# Altium schematic sheet Serial Monitor RS-232 Module Schematic.SchDoc("Serial Monitor RS-232 Module Schematic")
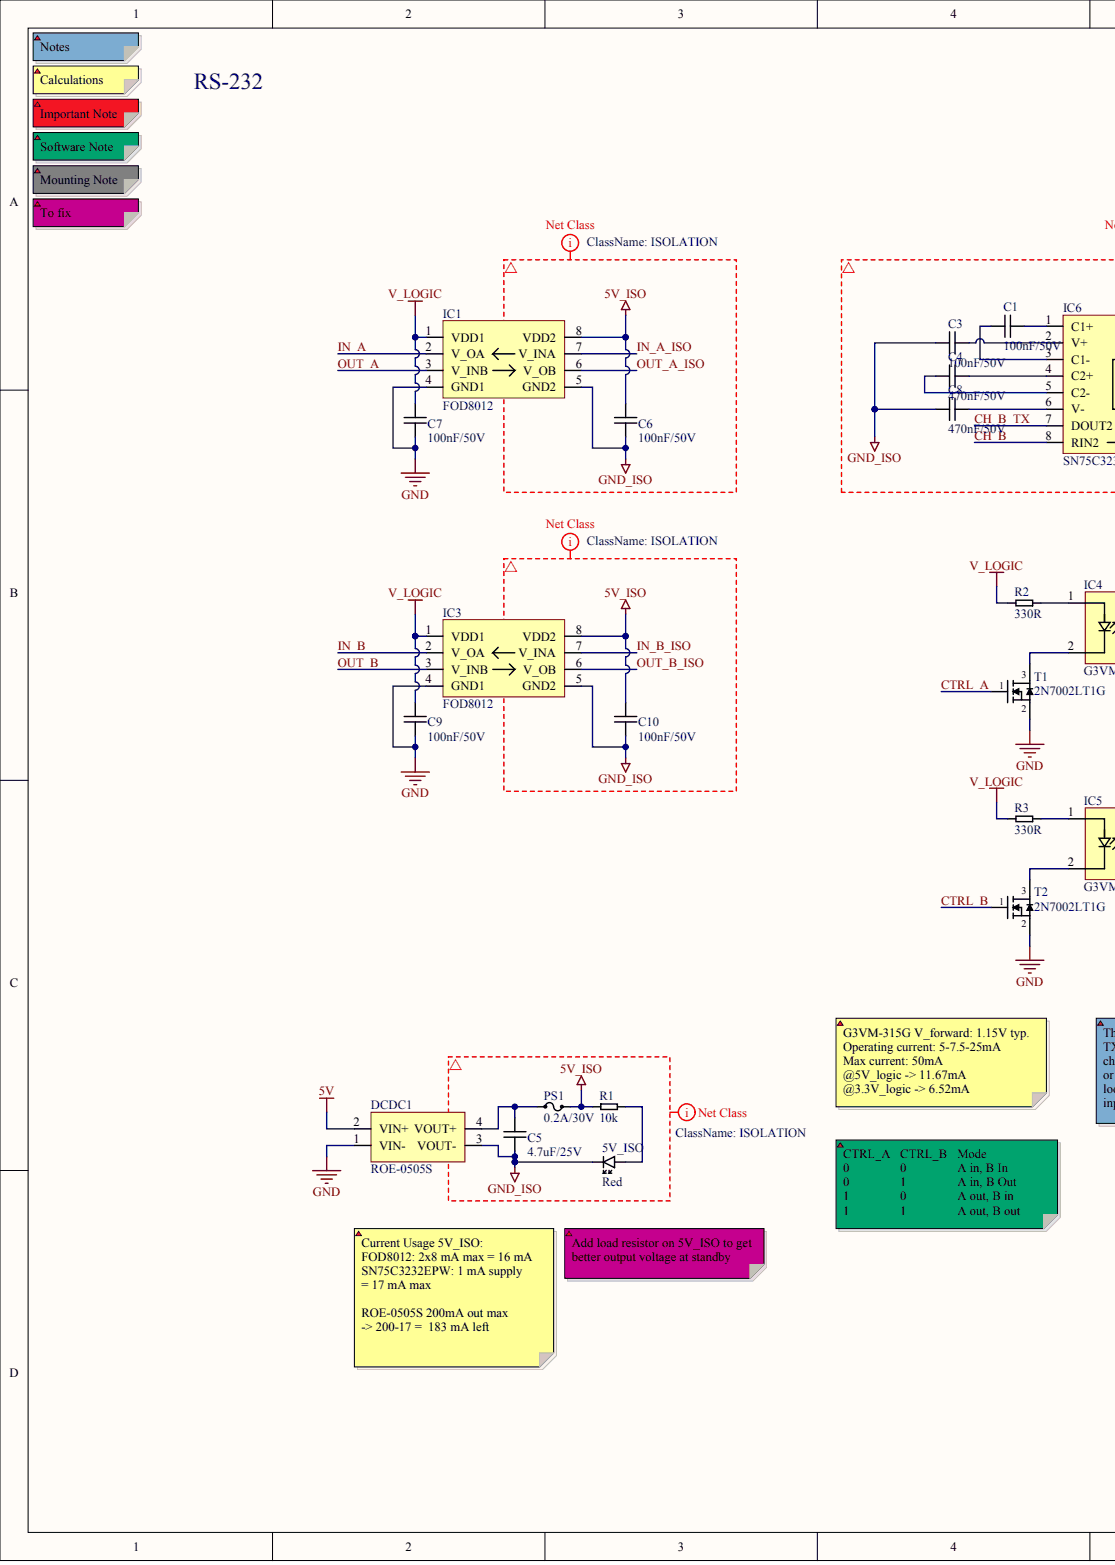
[diagram p0: Serial Monitor RS-232 Module Schematic.SchDoc, left]
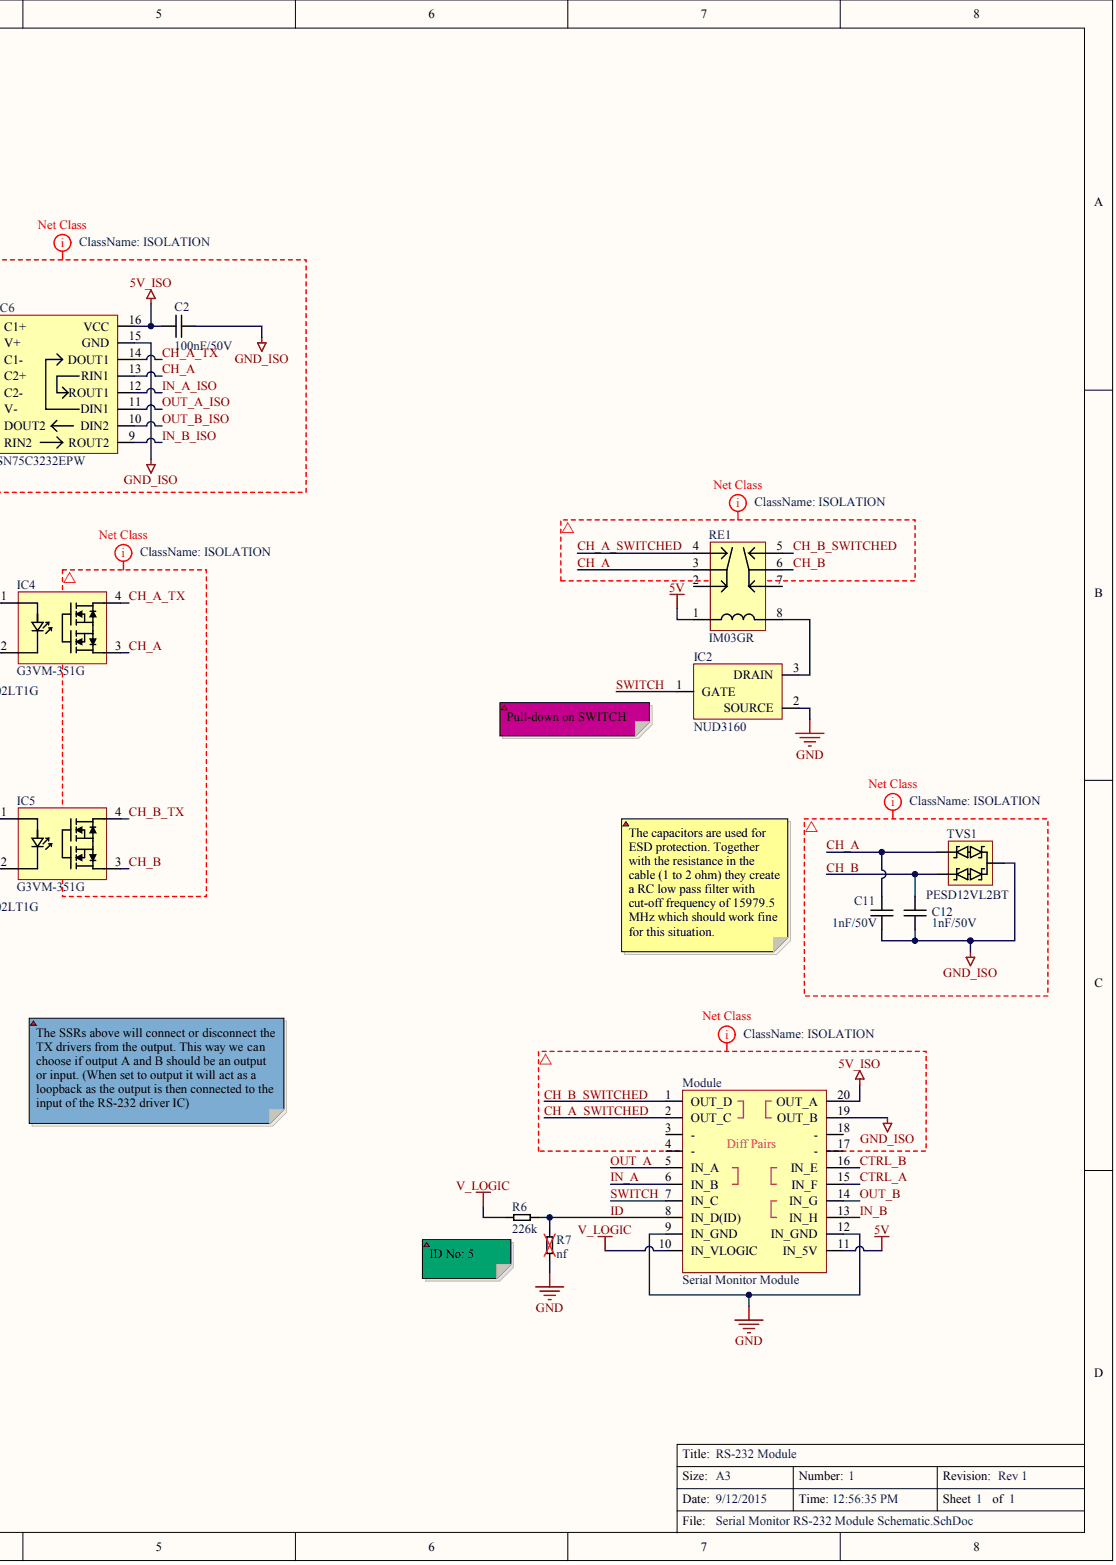
[diagram p1: Serial Monitor RS-232 Module Schematic.SchDoc, right]

## Parts
5V_ISO  value Red  (hidden comment: LED_0603_Red)
C1  value 100nF/50V  [0603]  (hidden comment: Cap_0603_100nF/50V)
C2  value 100nF/50V  [0603]  (hidden comment: Cap_0603_100nF/50V)
C3  value 100nF/50V  [0603]  (hidden comment: Cap_0603_100nF/50V)
C4  value 470nF/50V  [0603]  (hidden comment: Cap_0603_470nF/50V)
C5  value 4.7uF/25V  [0805]  (hidden comment: Cap_0805_4.7uF/25V)
C6  value 100nF/50V  [0603]  (hidden comment: Cap_0603_100nF/50V)
C7  value 100nF/50V  [0603]  (hidden comment: Cap_0603_100nF/50V)
C8  value 470nF/50V  [0603]  (hidden comment: Cap_0603_470nF/50V)
C9  value 100nF/50V  [0603]  (hidden comment: Cap_0603_100nF/50V)
C10  value 100nF/50V  [0603]  (hidden comment: Cap_0603_100nF/50V)
C11  value 1nF/50V  [0603]  (hidden comment: Cap_0603_1nF/50V)
C12  value 1nF/50V  [0603]  (hidden comment: Cap_0603_1nF/50V)
DCDC1  ROE-0505S  [4-SIP]
IC1  FOD8012
IC2  NUD3160
IC3  FOD8012
IC4  G3VM-351G
IC5  G3VM-351G
IC6  SN75C3232EPW
Module  Serial Monitor Module
PS1  value 0.2A/30V  [SMD 1206]  (hidden comment: Polyswitch_1206_0.2Ahold_30V)
R1  value 10k  [0603]  (hidden comment: Res_0603_10k)
R2  value 330R  [0603]  (hidden comment: Res_0603_330R)
R3  value 330R  [0603]  (hidden comment: Res_0603_330R)
R6  value 226k  [0603]  (hidden comment: Res_0603_226k)
R7  value nf  [0603]  (hidden comment: Res_0603_nf)
RE1  value IM03GR  Part No.: IM03GR  (hidden comment: Relay IM03GR)
T1  2N7002LT1G  [SOT-23]
T2  2N7002LT1G  [SOT-23]
TVS1  PESD12VL2BT

## Nets
5V: DCDC1.2(VIN+) Module.11(IN_5V) RE1.1
5V_ISO: C2.1 C6.2 C10.2 IC1.8(VDD2) IC3.8(VDD2) IC6.16(VCC) Module.20(OUT_A) PS1.2 R1.2
GND: C7.1 C9.1 DCDC1.1(VIN-) IC1.4(GND1) IC2.2 IC3.4(GND1) Module.9(IN_GND) Module.12(IN_GND) R7.2 T1.2 T2.2
GND_ISO: 5V_ISO.CATHODE C2.2 C3.2 C5.1 C6.1 C8.2 C10.1 C11.1 C12.2 DCDC1.3(VOUT-) IC1.5(GND2) IC3.5(GND2) IC6.15(GND) Module.19(OUT_B) TVS1.3
V_LOGIC: C7.2 C9.2 IC1.1(VDD1) IC3.1(VDD1) Module.10(IN_VLOGIC) R2.1 R3.1 R6.2
CH_A: C11.2 IC4.3 IC6.13(RIN1) RE1.3 TVS1.1
CH_A_SWITCHED: Module.2(OUT_C) RE1.4
CH_A_TX: IC4.4 IC6.14(DOUT1)
CH_B: C12.1 IC5.3 IC6.8(RIN2) RE1.6 TVS1.2
CH_B_SWITCHED: Module.1(OUT_D) RE1.5
CH_B_TX: IC5.4 IC6.7(DOUT2)
CTRL_A: Module.15(IN_F) T1.1
CTRL_B: Module.16(IN_E) T2.1
ID: Module.8(IN_D(ID)) R6.1 R7.1
IN_A: IC1.2(V_OA) Module.6(IN_B)
IN_A_ISO: IC1.7(V_INA) IC6.12(ROUT1)
IN_B: IC3.2(V_OA) Module.13(IN_H)
IN_B_ISO: IC3.7(V_INA) IC6.9(ROUT2)
OUT_A: IC1.3(V_INB) Module.5(IN_A)
OUT_A_ISO: IC1.6(V_OB) IC6.11(DIN1)
OUT_B: IC3.3(V_INB) Module.14(IN_G)
OUT_B_ISO: IC3.6(V_OB) IC6.10(DIN2)
SWITCH: IC2.1 Module.7(IN_C)
Net5V_ISO_ANODE: 5V_ISO.ANODE R1.1
NetC1_1: C1.1 IC6.1(C1+)
NetC1_2: C1.2 IC6.3(C1-)
NetC3_1: C3.1 IC6.2(V+)
NetC4_1: C4.1 IC6.4(C2+)
NetC4_2: C4.2 IC6.5(C2-)
NetC5_2: C5.2 DCDC1.4(VOUT+) PS1.1
NetC8_1: C8.1 IC6.6(V-)
NetIC2_3: IC2.3 RE1.8
NetIC4_1: IC4.1 R2.2
NetIC4_2: IC4.2 T1.3
NetIC5_1: IC5.1 R3.2
NetIC5_2: IC5.2 T2.3
N00037:
N00038:
N00039:
N00040:
N00041:
N00042:
N00043:
N00044:

Not connected: Module 3(-) 4(-) 17(-) 18(-); RE1 2 7

## Library data of the parts
5V_ISO = (no symbol name): Part No.: APT1608SRCPRV; Manufacturer: Kingbright; RS: 654-5420; DigiKey: 754-1122-1-ND; Price SEK (No VAT): 1; Mouser: 604-APT1608SRCPRV
C1-C3 C6 C7 C9 C10 = (no symbol name): Part No.: C1608X7R1H104K080AA; Manufacturer: TDK; RS: 741-4459 (500-pack); DigiKey: 445-1314-1-ND; Mouser: 810-C1608X7R1H104K; Price SEK (No VAT): 0,2
  C1: Value: 100nF/50V
C4 C8 = (no symbol name): Part No.: C1608X7R1H474K080AC; Manufacturer: TDK; RS: 788-3007 (50-pack); DigiKey: 445-5952-1-ND; Mouser: 810-C1608X7R1H474K; Price SEK (No VAT): 0,5
C5 = (no symbol name): Part No.: GRM21BR61E475KA12L; Manufacturer: Murata; DigiKey: 490-3335-1-ND; Mouser: 81-GRM21BR61E475KA12; Price SEK (No VAT): 1
C11 C12 = (no symbol name): Part No.: C1608X7R1H102K080AE; Manufacturer: TDK; Mouser: 810-C1608X7R1H102KAE; DigiKey: 445-15923-1-ND; Price SEK (No VAT): 0,4
DCDC1 = (no symbol name): Manufacturer: Recom Power; DigiKey: 945-1655-5-ND; Part No.: ROE-0505S; Price SEK (No VAT): 20; Mouser: 919-ROE-0505S; Value: ROE-0505S
IC1 IC3 = (no symbol name): Manufacturer: Fairchild Semiconductor; Note: Should be able to replace ADUM1201 as pin-compatible; DigiKey: FOD8012-ND; Part No.: FOD8012; Price SEK (No VAT): 31; Datasheet: http://www.fairchildsemi.com/ds/FO/FOD8012.pdf; Mouser: 512-FOD8012; Value: FOD8012
IC2 = (no symbol name): Part No.: NUD3160LT1G; Manufacturer: ON; DigiKey: NUD3160LT1GOSCT-ND; Price SEK (No VAT): 5; Datasheet: http://www.onsemi.com/pub_link/Collateral/NUD3160-D.PDF; Mouser: 863-NUD3160LT1G; Value: NUD3160
IC4 IC5 = (no symbol name): Manufacturer: Omron; DigiKey: Z2084-ND; Part No.: G3VM-351G; Price SEK (No VAT): 23; Datasheet: http://www.omron.com/ecb/products/pdf/en-g3vm_351g.pdf; Mouser: 653-G3VM-351G; Value: G3VM-351G
IC6 = (no symbol name): Manufacturer: TI; DigiKey: 296-21082-1-ND; Part No.: SN75C3232EPW; Price SEK (No VAT): 19; Datasheet: http://www.ti.com/lit/ds/symlink/sn75c3232e.pdf; Mouser: 595-SN75C3232EPWR; Value: SN75C3232EPW
PS1 = (no symbol name): Part No.: 0ZCJ0020FF2E; Manufacturer: Bel Fuse; Mouser: 530-0ZCJ0020FF2E; Price SEK (No VAT): 1; DigiKey: 507-1797-1-ND; RS: *; Farnell: *
R1 = (no symbol name): Part No.: CRCW060310K0FKEA; Manufacturer: Vishay; Price SEK (No VAT): 0,1; Mouser: 71-CRCW0603-10K-E3; DigiKey: 541-10.0KHCT-ND
R2 R3 = (no symbol name): Part No.: CRCW0603330RFKEA; Manufacturer: Vishay; Price SEK (No VAT): 0,1; Mouser: 71-CRCW0603-330-E3; DigiKey: 541-330HCT-ND
R6 = (no symbol name): Part No.: CRCW0603226KFKEA; Manufacturer: Vishay; DigiKey: 541-226KHCT-ND; Mouser: 71-CRCW0603-226K-E3; Price SEK (No VAT): 0,1
RE1 = (no symbol name): Manufacturer: TE; Mouser: 655-IM03GR; DigiKey: PB1061CT-ND; Price SEK (No VAT): 28; Datasheet: http://www.te.com/commerce/DocumentDelivery/DDEController?Action=srchrtrv&DocNm=108-98001&DocType=SS&DocLang=EN
T1 T2 = (no symbol name): Manufacturer: ON Semiconductor; Mouser: 863-2N7002LT1G; Part No.: 2N7002LT1G; DigiKey: 2N7002LT1GOSCT-ND; Price SEK (No VAT): 2; Value: 2N7002LT1G
TVS1 = (no symbol name): Manufacturer: NXP; Part No.: PESD12VL2BT,215; Mouser: 771-PESD12VL2BT-T/R; DigiKey: 568-4023-1-ND; Price SEK (No VAT): 4; Value: PESD12VL2BT
Module R7 = (no symbol name)
  Module: Value: Serial Monitor Module
  R7: Price SEK (No VAT): 0
